# Altium schematic sheet Open Curator-Base.SchDoc
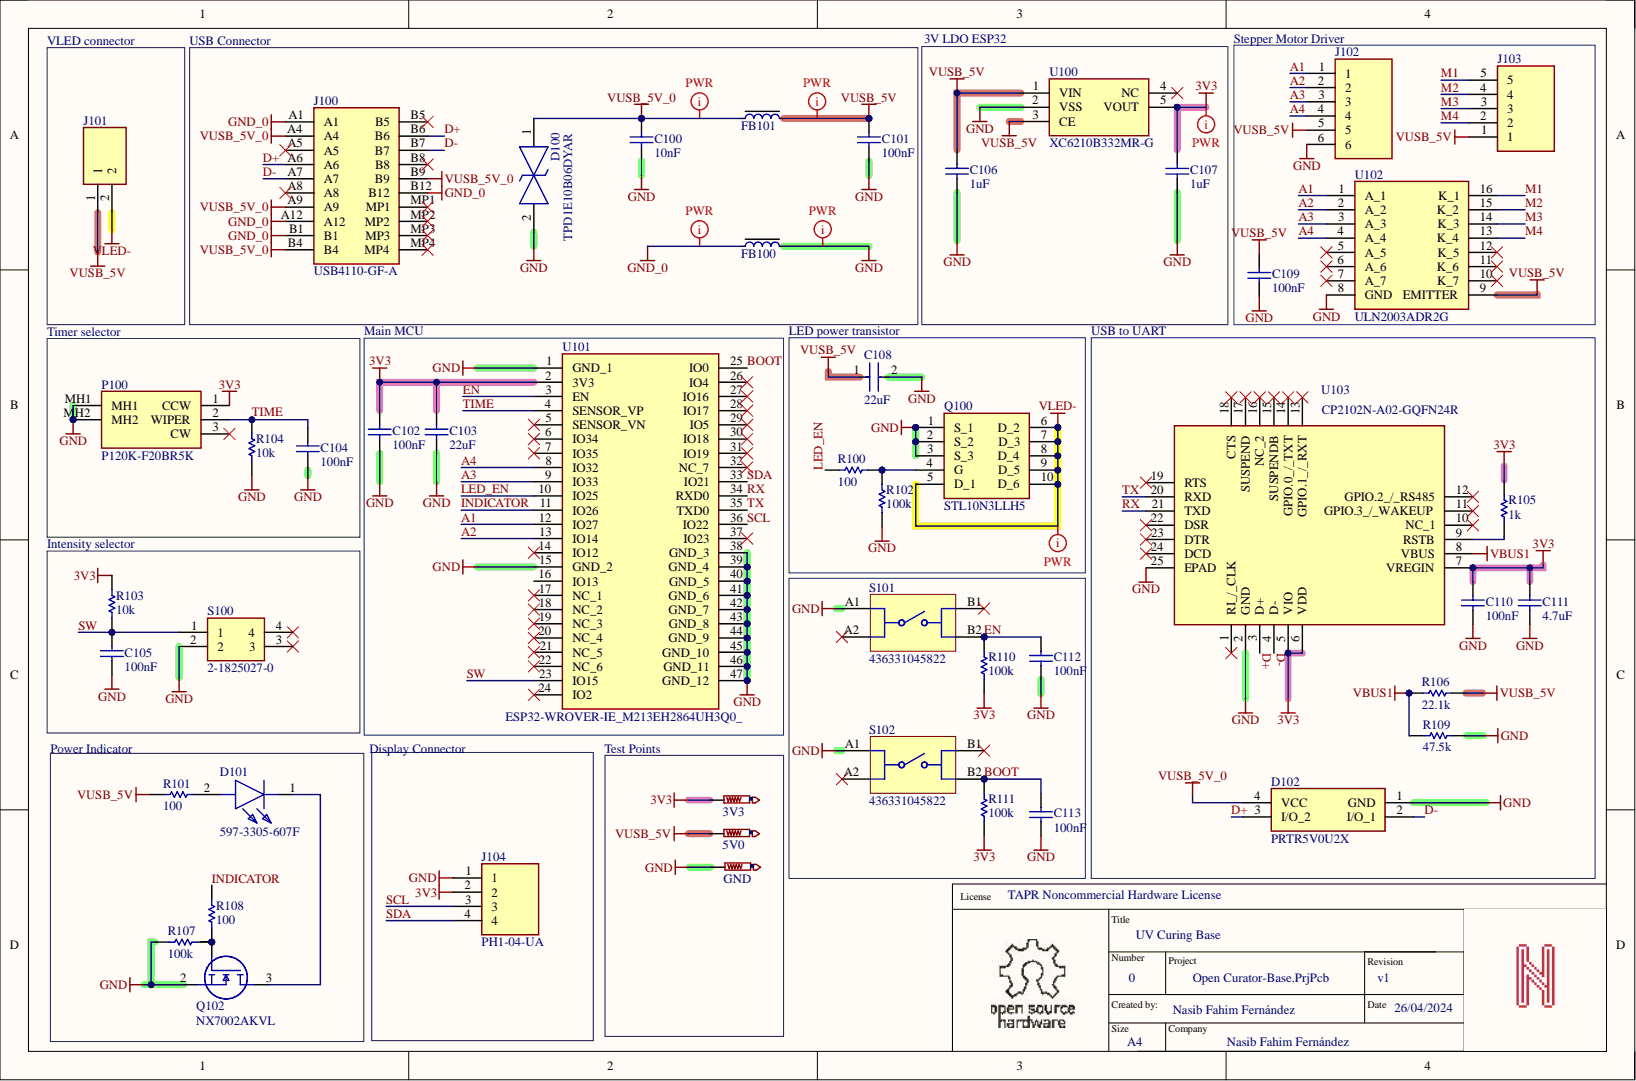
[diagram p0: Open Curator-Base.SchDoc]

## Parts
3V3  TEST_PAD1.0round  [TestPoint]  (value not drawn)  (no BOM)
5V0  TEST_PAD1.0round  [TestPoint]  (value not drawn)  (no BOM)
C100  10nF  [CAPC1005X55X25LL5T10]
C101  100nF  [CAPC1005X55X25LL5T10]
C102  100nF  [CAPC1005X55X25LL5T10]
C103  22uF  [CAPC1608X90X35LL10T15]
C104  100nF  [CAPC1005X55X25LL5T10]
C105  100nF  [CAPC1005X55X25LL5T10]
C106  1uF  [CAPC1005X55X25LL5T10]
C107  1uF  [CAPC1005X55X25LL5T10]
C108  22uF  [FP-C2012-125-0_2-MFG]
C109  100nF  [CAPC1005X55X25LL5T10]
C110  100nF  [CAPC1005X55X25LL5T10]
C111  4.7uF  [CAPC1005X55X25LL5T10]
C112  100nF  [CAPC1005X55X25LL5T10]
C113  100nF  [CAPC1005X55X25LL5T10]
D100  TPD1E10B06DYAR  [SODFL1608X77N]
D101  597-3305-607F  [LEDC2012X70N]
D102  PRTR5V0U2X  [PRTR5V0U2X]
FB100  =Value  [BEADC1608X95N]
FB101  =Value  [BEADC1608X95N]
GND  TEST_PAD1.0round  [TestPoint]  (value not drawn)  (no BOM)
J100  USB4110-GF-A  [USB4110GFA]
J101  B2B-XH-AM_LF__SN_  [B2BXHAMLFSN]  (value not drawn)
J102  G800W302018EU  [HDRV6W69P0X254_1X6_1524X250X895P]  (value not drawn)
J103  B5B-XH-AM__LF__SN_  [B5BXHAMLFSN]  (value not drawn)
J104  PH1-04-UA  [HDRV4W64P0X254_1X4_1016X250X901P]
P100  P120K-F20BR5K  [P120KF20BR5K]
Q100  STL10N3LLH5  [STL10N3LLH5]
Q102  NX7002AKVL  [SOT95P230X110-3N]
R100  100  [RESC1005X40X25LL05T05]
R101  100  [RESC1005X40X25LL05T05]
R102  100k  [RESC1005X40X25LL05T05]
R103  10k  [RESC1005X40X25ML05T05]
R104  10k  [RESC1005X40X25ML05T05]
R105  1k  [RESC1005X40X25LL05T05]
R106  22.1k  [RESC1005X40X25LL05T05]
R107  100k  [RESC1005X40X25LL05T05]
R108  100  [RESC1005X40X25LL05T05]
R109  47.5k  [RESC1005X40X25LL05T05]
R110  100k  [RESC1005X40X25LL05T05]
R111  100k  [RESC1005X40X25LL05T05]
S100  2-1825027-0  [218250270]
S101  436331045822  [436331045822]
S102  436331045822  [436331045822]
U100  XC6210B332MR-G  [SOT95P280X120-5N]
U101  ESP32-WROVER-IE_M213EH2864UH3Q0_  [ESP32WROVERIEM213EH2864UH3Q0]
U102  ULN2003ADR2G  [SOIC127P600X175-16N]
U103  CP2102N-A02-GQFN24R  [QFN50P400X400X80-25N-D]

## Nets
3V3: 3V3.PP C102.1 C103.2 C107.2 C110.1 C111.2 J104.2 P100.1(CCW) R103.2 R105.1 R110.1 R111.1 U100.5(VOUT) U101.2(3V3) U103.5(VIO) U103.6(VDD) U103.7(VREGIN)
GND: C100.1 C101.2 C102.2 C103.1 C104.2 C105.2 C106.1 C107.1 C108.2 C109.2 C110.2 C111.1 C112.2 C113.2 D100.2 D102.1(GND) FB100.2 GND.PP J102.6 J104.1 P100.MH1 P100.MH2 Q100.1(S_1) Q100.2(S_2) Q100.3(S_3) Q102.2 R102.1 R104.1 R107.2 R109.1 S100.2 S101.A1 S102.A1 U100.2(VSS) U101.1(GND_1) U101.15(GND_2) U101.38(GND_3) U101.39(GND_4) U101.40(GND_5) U101.41(GND_6) U101.42(GND_7) U101.43(GND_8) U101.44(GND_9) U101.45(GND_10) U101.46(GND_11) U101.47(GND_12) U102.8(GND) U103.2(GND) U103.25(EPAD)
GND_0: FB100.1 J100.A1 J100.A12 J100.B1 J100.B12
VBUS1: R106.2 R109.2 U103.8(VBUS)
VLED-: J101.2 Q100.5(D_1) Q100.6(D_2) Q100.7(D_3) Q100.8(D_4) Q100.9(D_5) Q100.10(D_6)
VUSB_5V: 5V0.PP C101.1 C106.2 C108.1 C109.1 FB101.2 J101.1 J102.5 J103.1 R101.1 R106.1 U100.1(VIN) U100.3(CE) U102.9(EMITTER)
VUSB_5V_0: C100.2 D100.1 D102.4(VCC) FB101.1 J100.A4 J100.A9 J100.B4 J100.B9
A1: J102.1 U101.12(IO27) U102.1(A_1)
A2: J102.2 U101.13(IO14) U102.2(A_2)
A3: J102.3 U101.9(IO33) U102.3(A_3)
A4: J102.4 U101.8(IO32) U102.4(A_4)
BOOT: C113.1 R111.2 S102.B2 U101.25(IO0)
D+: D102.3(I/O_2) J100.A6 J100.B6 U103.3(D+)
D-: D102.2(I/O_1) J100.A7 J100.B7 U103.4(D-)
EN: C112.1 R110.2 S101.B2 U101.3(EN)
INDICATOR: R108.2 U101.11(IO26)
LED_EN: R100.1 U101.10(IO25)
M1: J103.5 U102.16(K_1)
M2: J103.4 U102.15(K_2)
M3: J103.3 U102.14(K_3)
M4: J103.2 U102.13(K_4)
RX: U101.34(RXD0) U103.21(TXD)
SCL: J104.3 U101.36(IO22)
SDA: J104.4 U101.33(IO21)
SW: C105.1 R103.1 S100.1 U101.23(IO15)
TIME: C104.1 P100.2(WIPER) R104.2 U101.4(SENSOR_VP)
TX: U101.35(TXD0) U103.20(RXD)
NetD101_1: D101.1 Q102.3
NetD101_2: D101.2 R101.2
NetQ100_4: Q100.4(G) R100.2 R102.2
NetQ102_1: Q102.1 R107.1 R108.1
NetR105_2: R105.2 U103.9(RSTB)

Not connected: J100 A5 A8 B5 B8 MP1 MP2 MP3 MP4; P100 3(CW); S100 3 4; S101 A2 B1; S102 A2 B1; U100 4(NC); U101 5(SENSOR_VN) 6(IO34) 7(IO35) 14(IO12) 16(IO13) 17(NC_1) 18(NC_2) 19(NC_3) 20(NC_4) 21(NC_5) 22(NC_6) 24(IO2) 26(IO4) 27(IO16) 28(IO17) 29(IO5) 30(IO18) 31(IO19) 32(NC_7) 37(IO23); U102 5(A_5) 6(A_6) 7(A_7) 10(K_7) 11(K_6) 12(K_5); U103 1(RI_/_CLK) 10(NC_1) 11(GPIO.3_/_WAKEUP) 12(GPIO.2_/_RS485) 13(GPIO.1_/_RXT) 14(GPIO.0_/_TXT) 15(SUSPENDB) 16(NC_2) 17(SUSPEND) 18(CTS) 19(RTS) 22(DSR) 23(DTR) 24(DCD)

## Library data of the parts
3V3 5V0 GND = TEST_PAD1.0round: Test Point Leesys Specs; Library Name: Open Curator-Base.SCHLIB
C100 = CMP-1662-00017-1: 0.01 uF 16 VDC 20% 0402 X7R; Manufacturer: Murata; Part Number: GRM155R71C103MA01D; Component Kind: Standard; Library Name: Altium Content Vault; Value: 10nF
C101 C102 C104 C105 C109 C110 C112 C113 = CMP-1662-00017-1: CAP, MLCC, 0402/1005, 25V, X7R,; Manufacturer: Murata; Part Number: GRM155R71E104KE14D; Component Kind: Standard; Library Name: Altium Content Vault; Value: 100nF
C103 = CMP-2006-02884-1: CAP CER 22UF 6.3V X5R 0603; Manufacturer: Murata; Part Number: GRM188R60J226MEA0D; Component Kind: Standard; Library Name: Altium Content Vault; Value: 22uF
C106 C107 = CMP-1662-00017-1: 1.0uF 16V 10% 0402 X5R; Manufacturer: Murata; Part Number: GRM155R61C105KA12D; Component Kind: Standard; Library Name: Altium Content Vault; Value: 1uF
C108 = CMP-2000-06038-3: 0805 35VDC 22uF 20% X5R 1.25mm; Manufacturer: Murata; Part Number: C2012X5R1V226M125AC; Component Kind: Standard; Library Name: Altium Content Vault; Value: 22uF
C111 = CMP-1662-00017-1: 4.7uF 10V 20% 0402 X5R; Manufacturer: Murata; Part Number: GRM155R61A475MEAAD; Component Kind: Standard; Library Name: Altium Content Vault; Value: 4.7uF
D100 = TPD1E10B06DYAR: ESD /  TVS diode 12-pF, +/-5.5V, +/-30-kV ESD protection diode in 0402 and SOD-523 package; Manufacturer: Texas Instruments; Component Kind: Standard; Height: 0.77mm; Mouser Part Number: 595-TPD1E10B06DYAR; Part Number: TPD1E10B06DYAR
D101 = 597-3305-607F: LED; Manufacturer: Dialight; Arrow Part Number: 597-3305-607F; Arrow Price/Stock: https://www.arrow.com/en/products/597-3305-607f/dialight; Component Kind: Standard; Datasheet Link: https://mouser.componentsearchengine.com/Datasheets/1/597-3305-607F.pdf; Height: 0.7mm; Mouser Part Number: 645-597-3305-607F; Mouser Price/Stock: https://www.mouser.com/Search/Refine.aspx?Keyword=645-597-3305-607F; Part Number: 597-3305-607F
D102 = PRTR5V0U2X: ESD Diode; Manufacturer: Nexperia; Component Kind: Standard; Datasheet Link: https://assets.nexperia.com/documents/data-sheet/PRTR5V0U2X.pdf; Height: 1.1mm; Mouser Part Number: N/A; Mouser Price/Stock: https://www.mouser.co.uk/ProductDetail/Nexperia/PRTR5V0U2X?qs=vHuUswq2%252BsxqVTVM0kNyWw%3D%3D; Part Number: PRTR5V0U2X
FB100 FB101 = FB0402-120R@100M-500mA: Ferrite Bead, 2A @ 100MHz, 120ohms 25%; Manufacturer: Vishay; Part Number: ILHB0603ER121V; Database Table Name: Parts$; Component Kind: Standard; ComponentLink1Description: Datasheet; ComponentLink1URL: N.A.; ComponentLink2Description: Supplier link; ComponentLink2URL: N.A.; Library Name: AltiumLib.DbLib; Author: rsk
J100 = USB4110-GF-A: Connector; Manufacturer: GCT (GLOBAL CONNECTOR TECHNOLOGY); Component Kind: Standard; Datasheet Link: https://gct.co/files/drawings/usb4110.pdf; Height: 3.26mm; Library Name: SamacSys.SchLib; Mouser Part Number: 640-USB4110-GF-A; Mouser Price/Stock: https://www.mouser.co.uk/ProductDetail/GCT/USB4110-GF-A?qs=KUoIvG%2F9IlYiZvIXQjyJeA%3D%3D; Part Number: USB4110-GF-A
J101 = B2B-XH-AM_LF__SN_: Connector; Manufacturer: JST (JAPAN SOLDERLESS TERMINALS); Part Number: B2B-XH-AM(LF)(SN); Arrow Part Number: B2B-XH-AM(LF)(SN); Arrow Price/Stock: https://www.arrow.com/en/products/b2b-xh-am-lf-sn/jst-manufacturing?region=europe; Component Kind: Standard; Datasheet Link: https://www.jst-mfg.com/product/pdf/eng/eXH.pdf; Height: 7mm
J102 = G800W302018EU: Connector; Manufacturer: Amphenol Communications Solutions; Component Kind: Standard; Datasheet Link: https://cdn.amphenol-cs.com/media/wysiwyg/files/drawing/g800wxxxxxxeu_1.pdf; Height: 8.95mm; Part Number: G800W302018EU
J103 = B5B-XH-AM__LF__SN_: Connector; Manufacturer: JST (JAPAN SOLDERLESS TERMINALS); Part Number: B5B-XH-AM (LF)(SN); Arrow Part Number: B5B-XH-AM (LF)(SN); Arrow Price/Stock: https://www.arrow.com/en/products/b5b-xh-am-lf-sn/jst-manufacturing; Component Kind: Standard; Datasheet Link: http://uk.rs-online.com/web/p/products/1755317; Height: 7mm
J104 = PH1-04-UA: Connector; Manufacturer: Adam Tech; Arrow Part Number: PH1-04-UA; Arrow Price/Stock: https://www.arrow.com/en/products/ph1-04-ua/adam-tech?region=nac; Component Kind: Standard; Datasheet Link: https://datasheet.datasheetarchive.com/originals/distributors/Datasheets_SAMA/22522227e000bb8d8f26a3580c1bb98b.pdf; Height: 9.008mm; Part Number: PH1-04-UA
P100 = P120K-F20BR5K: Pot  12mm 5Kohm; Manufacturer: TT Electronics; Component Kind: Standard; Datasheet Link: http://www.ttelectronics.com/sites/default/files/download-files/Datasheet_RotaryPanelPot_P120series.pdf; Height: 16.2mm; Mouser Part Number: 858-P120KF20BR5K; Mouser Price/Stock: https://www.mouser.co.uk/ProductDetail/BI-Technologies-TT-Electronics/P120K-F20BR5K/?qs=XMFZpxCDm8%252BSqZI5MGLVDQ%3D%3D; Part NUmber: P120K-F20BR5K
Q100 = STL10N3LLH5: MOSFET N-channel 30 V 0.015 Ohm 9A STripFET V; Manufacturer: STMicroelectronics; Arrow Part Number: STL10N3LLH5; Arrow Price/Stock: https://www.arrow.com/en/products/stl10n3llh5/stmicroelectronics; Component Kind: Standard; Datasheet Link: https://www.st.com/resource/en/datasheet/stl10n3llh5.pdf; Height: 1mm; Mouser Part Number: 511-STL10N3LLH5; Mouser Price/Stock: https://www.mouser.co.uk/ProductDetail/STMicroelectronics/STL10N3LLH5?qs=0gj%2FgiofzsOGxczN3DPYlA%3D%3D; Part Number: STL10N3LLH5
Q102 = NX7002AKVL: MOSFET (N-Channel); Manufacturer: Nexperia; Arrow Part Number: NX7002AKVL; Arrow Price/Stock: https://www.arrow.com/en/products/nx7002akvl/nexperia?region=europe; Component Kind: Standard; Datasheet Link: https://www.arrow.com/en/products/nx7002akvl/nexperia; Height: 1.1mm; Mouser Part Number: 771-NX7002AKVL; Mouser Price/Stock: https://www.mouser.co.uk/ProductDetail/Nexperia/NX7002AKVL?qs=1GAP6L74MtTHifGD7E%252BJaw%3D%3D; Part Number: NX7002AKVL
R100 R101 R108 = CMP-2002-08382-1: RES SMD 100 OHM 1% 1/16W 0402; Manufacturer: Vishay; Part Number: MCS04020D1000BE000; Component Kind: Standard; Library Name: Altium Content Vault; Value: 100
R102 R107 R110 R111 = CMP-2002-08382-1: 100 kOhms 62.5mW 0402 1%; Manufacturer: Yageo; Part Number: RC0402FR-07100KL; Component Kind: Standard; Library Name: Altium Content Vault; Value: 100k
R103 R104 = CMP-1011-00668-2: RES SMD 10K OHM 1% 1/16W 0402; Manufacturer: Stackpole Electronics Inc; Part Number: SFR01MZPF1002; Component Kind: Standard; Library Name: Altium Content Vault; Value: 10k
R105 = CMP-2002-08382-1: RES SMD 1K OHM 1% 1/16W 0402; Manufacturer: Yageo; Part Number: CRT0402-FZ-1001GST; Component Kind: Standard; Library Name: Altium Content Vault; Value: 1k
R106 = CMP-2002-08382-1: RES SMD 22.1K OHM 1% 1/16W 0402; Manufacturer: Stackpole Electronics Inc; Part Number: RT0402BRE0722K1L; Component Kind: Standard; Library Name: Altium Content Vault; Value: 22.1k
R109 = CMP-2002-08382-1: RES SMD 47.5K OHM 1% 1/16W 0402; Manufacturer: Stackpole Electronics Inc; Part Number: RN731ETTP4752F50; Component Kind: Standard; Library Name: Altium Content Vault; Value: 47.5k
S100 = 2-1825027-0: Connector; Manufacturer: TE Connectivity; Arrow Part Number: 2-1825027-0; Arrow Price/Stock: https://www.arrow.com/en/products/2-1825027-0/te-connectivity; Component Kind: Standard; Datasheet Link: https://www.te.com/commerce/DocumentDelivery/DDEController?Action=showdoc&DocId=Customer+Drawing%7F1825027%7FG4%7Fpdf%7FEnglish%7FENG_CD_1825027_G4.pdf%7F2-1825027-0; Height: 7.01mm; Library Name: SamacSys.SchLib; Mouser Part Number: 506-2-1825027-0; Mouser Price/Stock: https://www.mouser.co.uk/ProductDetail/TE-Connectivity-PB/2-1825027-0?qs=sLzr0G66nuqhlS4AJQmBZQ%3D%3D; Part Number: 2-1825027-0
S101 S102 = CMP-1463-00001-3: button; Manufacturer: Wurth Elektronik; NexarPartId: 22862795; Contact Current Rating: 50mA; Contact Resistance: 100mΩ; Life (Cycles): 100kcycles; Voltage Rating: 12V; Component Kind: Standard; Contact Plating: Silver; Current Rating: 50mA; Height: 1.65mm; Insulation Resistance: 100MΩ; Library Name: Altium Content Vault; Max Operating Temperature: 85°C; Min Operating Temperature: -40°C; Mount: Surface Mount; Packaging: Tape and Reel; Part Number: 436331045822; RoHS: Compliant; Voltage Rating (DC): 12V; Width: 4.7mm
U100 = XC6210B302MR-G: 700mA High Speed LDO Regulator; Manufacturer: Torex; Component Kind: Standard; Datasheet Link: https://componentsearchengine.com/Datasheets/1/XC6210B302MR-G.pdf; Part Number: XC6210B332MR-G
U101 = ESP32-WROVER-IE_M213EH2864UH3Q0_: Wi-Fi - 802.11 SMD Module ESP32-WROVER-IE, ESP32-D0WD-V3, 3.3V 64Mbit PSRAM, 16 MB SPI flash, IPEX connector; Manufacturer: Espressif Systems; Part Number: ESP32-WROVER-IE(M213EH2864UH3Q0); Component Kind: Standard; Datasheet Link: https://www.mouser.at/datasheet/2/891/esp32-wrover-e_esp32-wrover-ie_datasheet_en-1855913.pdf; Height: 3.45mm; Mouser Part Number: 356-ESP32WRVIE2864UC; Mouser Price/Stock: https://www.mouser.co.uk/ProductDetail/Espressif-Systems/ESP32-WROVER-IEM213EH2864UH3Q0?qs=vmHwEFxEFR97Z2otHlKj0Q%3D%3D
U102 = ULN2003ADR2G: DARLINGTON TRNS ARRY; Manufacturer: onsemi; Arrow Part Number: ULN2003ADR2G; Arrow Price/Stock: https://www.arrow.com/en/products/uln2003adr2g/on-semiconductor?region=europe; Component Kind: Standard; Datasheet Link: https://www.onsemi.com/pdf/datasheet/uln2003a-d.pdf; Height: 1.75mm; Mouser Part Number: 863-ULN2003ADR2G; Mouser Price/Stock: https://www.mouser.co.uk/ProductDetail/onsemi/ULN2003ADR2G?qs=t2BMfLoP1KRgd83C6tnDAg%3D%3D; Part Number: ULN2003ADR2G
U103 = CP2102N-A02-GQFN24R: USB to UART Bridge QFN24; Manufacturer: Silicon Labs; Arrow Part Number: CP2102N-A02-GQFN24R; Arrow Price/Stock: https://www.arrow.com/en/products/cp2102n-a02-gqfn24r/silicon-labs; Component Kind: Standard; Datasheet Link: https://www.silabs.com/documents/public/data-sheets/cp2102n-datasheet.pdf; Height: 0.8mm; Mouser Part Number: 634-CP2102NA02QFN24R; Mouser Price/Stock: https://www.mouser.co.uk/ProductDetail/Silicon-Labs/CP2102N-A02-GQFN24R?qs=u16ybLDytRaIVBf0X4zL0g%3D%3D; Part Number: CP2102N-A02-GQFN24R
